# Altium schematic sheet DC_15V_5V.SchDoc
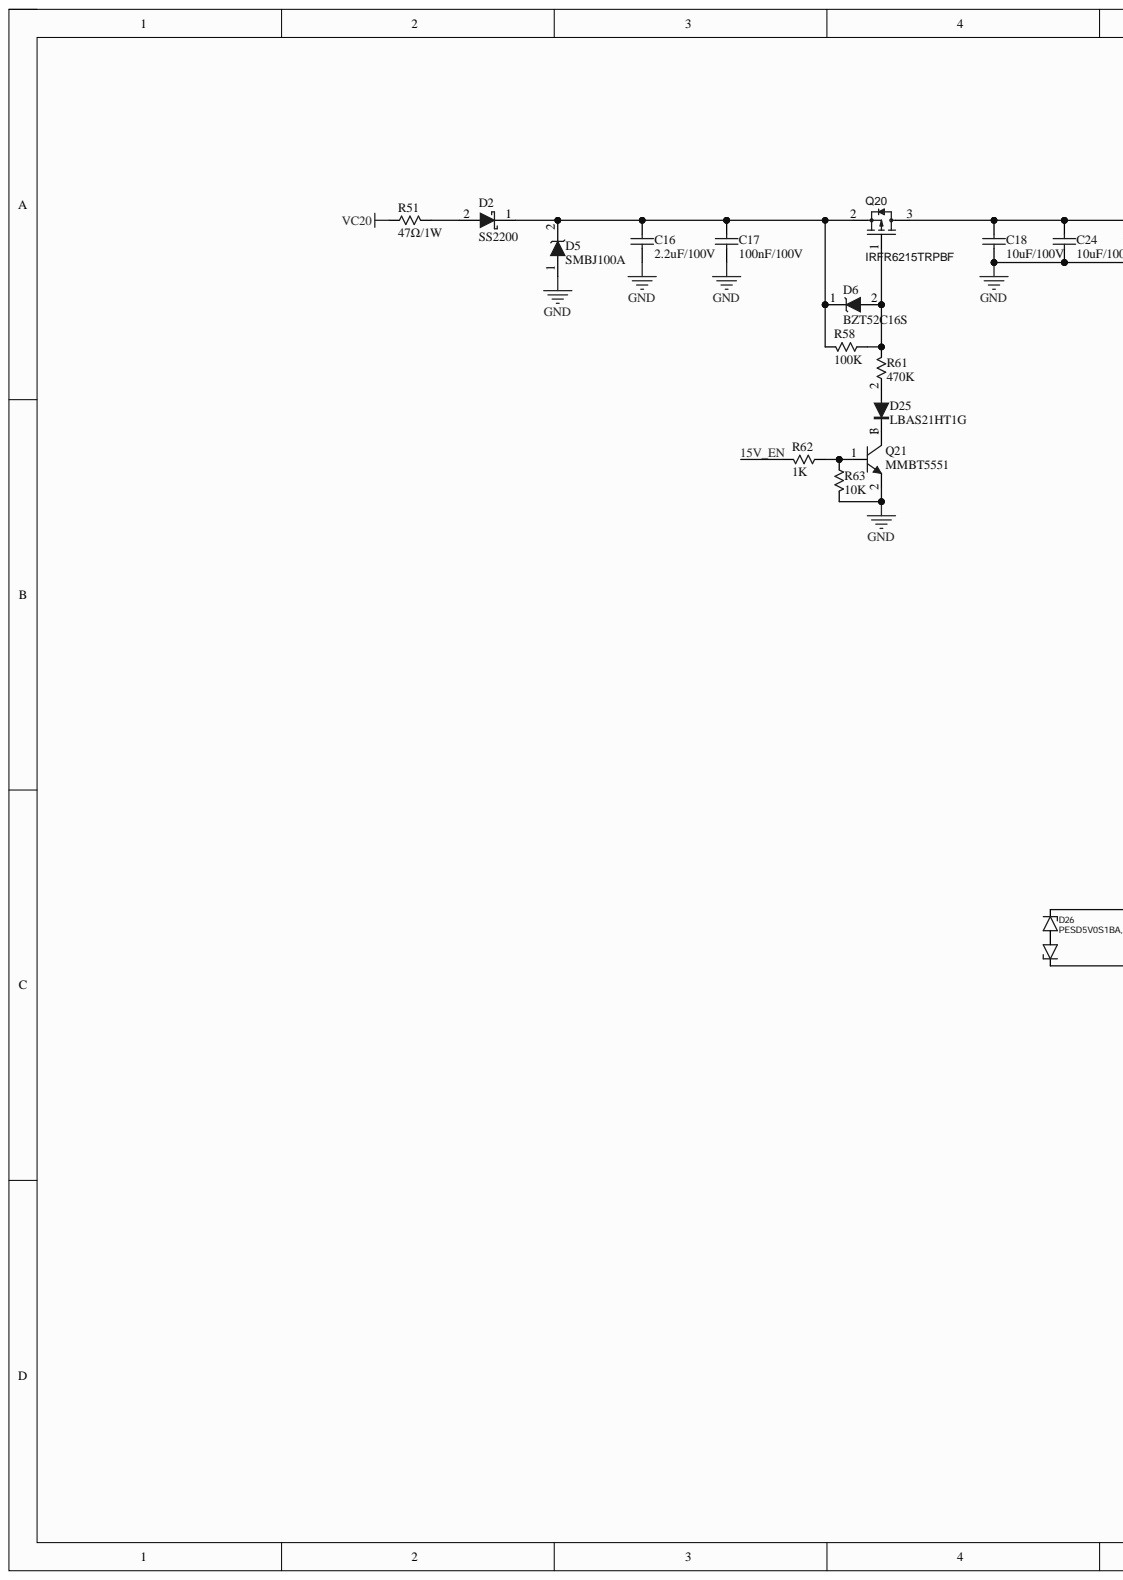
[diagram p0: DC_15V_5V.SchDoc, left]
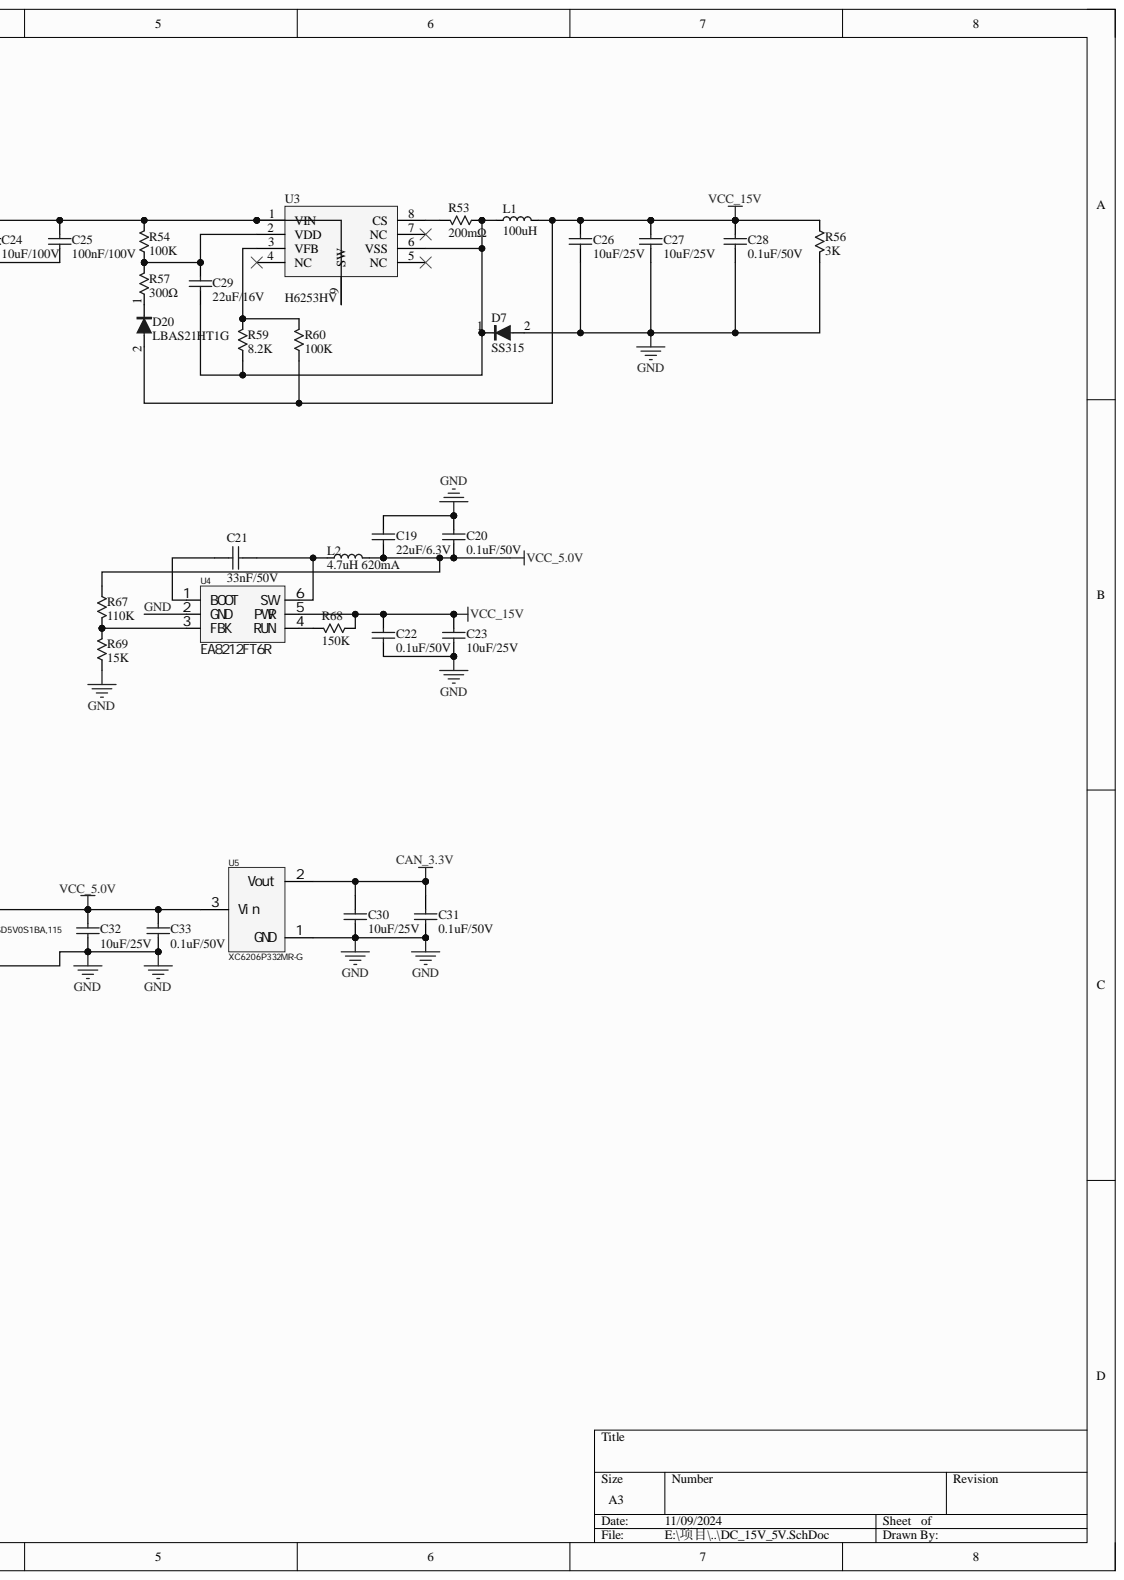
[diagram p1: DC_15V_5V.SchDoc, right]

## Parts
C16  2.2uF/100V  [0805C]
C17  100nF/100V  [0805C]
C18  10uF/100V  [1210C]
C19  22uF/6.3V  [0603_C]
C20  0.1uF/50V  [0603_C]
C21  33nF/50V  [0603_C]
C22  0.1uF/50V  [0603_C]
C23  10uF/25V  [0603_C]
C24  10uF/100V  [1210C]
C25  100nF/100V  [0805C]
C26  10uF/25V  [0603_C]
C27  10uF/25V  [0603_C]
C28  0.1uF/50V  [0603_C]
C29  22uF/16V  [0805C]
C30  10uF/25V  [0603_C]
C31  0.1uF/50V  [0603_C]
C32  10uF/25V  [0603_C]
C33  0.1uF/50V  [0603_C]
D2  SS2200  [SMA/DO-214AC]
D5  SMBJ100A  [SMB/DO-214AA]
D6  BZT52C16S  [SOD-323]
D7  SS315  [SMA/DO-214AC]
D20  LBAS21HT1G  [SOD-323]
D25  LBAS21HT1G  [SOD-323]
D26  PESD5V0S1BA,115  [SOD-323_BI]
L1  100uH  [IND-SMD_L6.0-W6.0_FNR60XXS]
L2  4.7uH 620mA  [L1210]
Q20  IRFR6215TRPBF  [TO_252_2]
Q21  MMBT5551  [SOT-23-3]
R51  47Ω/1W  [2512_R]
R53  200mΩ  [1206R]
R54  100K  [0603_R]
R56  3K  [0603_R]
R57  300Ω  [0603_R]
R58  100K  [0603_R]
R59  8.2K  [0603_R]
R60  100K  [0603_R]
R61  470K  [0603_R]
R62  1K  [0603_R]
R63  10K  [0603_R]
R67  110K  [0603_R]
R68  150K  [0603_R]
R69  15K  [0603_R]
U3  H6253HV  [ESOP-8]
U4  EA8212FT6R  [SOT-23-6]
U5  XC6206P332MR-G  [SOT-23-3]

## Nets
CAN_3.3V: C30.2 C31.1 U5.2
VC20: R51.2
VCC_5.0V: C19.2 C20.2 C32.2 C33.1 D26.1 L2.2 R67.2 U5.3
VCC_15V: C22.2 C23.2 C26.1 C27.1 C28.1 D20.2 L1.2 R56.2 R60.2 R68.2 U4.5(PWR)
15V_EN: R62.1
GND: C16.2 C17.2 C18.2 C19.1 C20.1 C22.1 C23.1 C24.2 C25.2 C26.2 C27.2 C28.2 C30.1 C31.2 C32.1 C33.2 D5.1 D7.2 D26.2 Q21.2 R56.1 R63.1 R69.2 U4.2(GND) U5.1
NetC16_1: C16.1 C17.1 D2.1 D5.2 D6.1 Q20.2 R58.1
NetC18_1: C18.1 C24.1 C25.1 Q20.3 R54.1 U3.1(VIN) U3.9(SW)
NetC21_1: C21.1 L2.1 U4.6(SW)
NetC21_2: C21.2 U4.1(BOOT)
NetC29_1: C29.1 R54.2 R57.1 U3.2(VDD)
NetC29_2: C29.2 D7.1 L1.1 R53.2 R59.2 U3.6(VSS)
NetD2_2: D2.2 R51.1
NetD6_2: D6.2 Q20.1 R58.2 R61.1
NetD20_1: D20.1 R57.2
NetD25_1: D25.1 Q21.3
NetD25_2: D25.2 R61.2
NetQ21_1: Q21.1 R62.2 R63.2
NetR53_1: R53.1 U3.8(CS)
NetR59_1: R59.1 R60.1 U3.3(VFB)
NetR67_1: R67.1 R69.1 U4.3(FBK)
NetR68_1: R68.1 U4.4(RUN)

Not connected: U3 4(NC) 5(NC) 7(NC)

## Library data of the parts
C16-C33 = Cap: Capacitor; Component Kind: Standard; LatestRevisionDate: 17-Jul-2002; LatestRevisionNote: Re-released for DXP Platform.; PackageReference: RAD-0.3; Published: 8-Jun-2000; Signal Integrity: Cap; Simulation: CAP; Library Name: UP-ROBOT Devices.SchLib; Publisher: Altium Limited
  C16: Value: 2.2uF/100V
  C17 C25: Value: 100nF/100V
  C18 C24: Value: 10uF/100V
  C19: Value: 22uF/6.3V
  C20 C22 C28 C31 C33: Value: 0.1uF/50V
  C21: Value: 33nF/50V
  C23 C26 C27 C30 C32: Value: 10uF/25V
  C29: Value: 22uF/16V
D7 D20 D25 = 1N4148W: Component Kind: Standard; Library Name: DVC1124_20S.SchLib
D26 = PESD5V0S1BA,115: Manufacturer: Nexperia(安世); Add into BOM: yes; Breakdown Voltage: 5.5V; Maximum Clamping Voltage: 14V; Peak Pulse Current (Ipp)@10/1000us: 12A; Peak Pulse Power Dissipation (Ppp)@10/1000us: 130W; polarity: 双向; Reverse Stand-Off Voltage (Vrwm): 5V; Type: TVS; Component Kind: Standard; Convert to PCB: yes; Datasheet: https://atta.szlcsc.com/upload/public/pdf/source/20161024/1477288630856.pdf; Device: PESD5V0S1BA,115; JLCPCB Part Class: Extended Part; LCSC Part Name: PESD5V0S1BA,115; Library Name: BMS_20S_MCU_MOS.SchLib; Manufacturer Part: PESD5V0S1BA,115; Supplier: LCSC; Supplier Footprint: SOD-323; Supplier Part: C19224; Symbol: PESD5V0S1BA,115
L1 = Inductor: FNR6045S101MT; Code_IEC: 1005; Code_JEITA: 0402; Component Kind: Standard; LatestRevisionDate: 17-Jul-2002; LatestRevisionNote: Re-released for DXP Platform.; PackageReference: 0402-A; Published: 8-Jun-2000; Signal Integrity: Inductor; Simulation: INDUCTOR; Value: 100uH; Library Name: UP-ROBOT Devices.SchLib; Publisher: Altium Limited
L2 = Inductor: NLCV32T-4R7M-PF; Component Kind: Standard; ComponentLink1Description: 淘宝; ComponentLink1URL: https://item.taobao.com/item.htm?spm=a1z09.2.0.0.2c712e8doApNwR&id=548266716017&_u=o27ne5fpe679; Library Name: MY_SCHLIB.SchLib
Q20 = PMOS: BOM_Manufacturer: Ncepower; BOM_Manufacturer Part: NCE0102; Contributor: LCSC; BOM_JLCPCB Part Class: Extended Part; BOM_Supplier: LCSC; BOM_Supplier Part: C161843; Component Kind: Standard; Library Name: BMS_20S_MCU_MOS.SchLib; spicePre: Q; spiceSymbolName: NCE0102
Q21 = NPN: Component Kind: Standard; Library Name: Three_Axis_Arm_Control_V1.1.SchLib
R51 R53 R54 R56-R63 R67-R69 = Res: Resistor; Component Kind: Standard; LatestRevisionDate: 17-Jul-2002; LatestRevisionNote: Re-released for DXP Platform.; PackageReference: AXIAL-0.3; Published: 8-Jun-2000; Signal Integrity: Res; Simulation: RESISTOR; Library Name: UP-ROBOT Devices.SchLib; Publisher: Altium Limited
  R51: Value: 47Ω/1W
  R53: Value: 200mΩ
  R54 R58 R60: Value: 100K
  R56: Value: 3K
  R57: Value: 300Ω
  R59: Value: 8.2K
  R61: Value: 470K
  R62: Value: 1K
  R63: Value: 10K
  R67: Value: 110K
  R68: Value: 150K
  R69: Value: 15K
U4 = EA8212FT6R: Manufacturer: everanalog(申风); Add into BOM: yes; Function: 降压型; Input Voltage: 4.5V~18V; Output Current (Max): 2A; Output Voltage: 900mV~12V; Switch tube (built-in/external): 内置; Switching Frequency: 500kHz; Component Kind: Standard; Convert to PCB: yes; Datasheet: https://atta.szlcsc.com/upload/public/pdf/source/20230315/38959F4CF9BF836C9EE97E62D66D6936.pdf; Device: EA8212FT6R; JLCPCB Part Class: Extended Part; LCSC Part Name: EA8212FT6R; Library Name: DCDC_Test.SchLib; Manufacturer Part: EA8212FT6R; Number of Outputs: -; Operating Temperature: -; Output Type: -; Quiescent Current (Iq): -; Supplier: LCSC; Supplier Footprint: SOT-23-6; Supplier Part: C5369100; Symbol: EA8212FT6R; Synchronous Rectification: -; Topology: -
U5 = XC6206P332MR-G: Manufacturer: TOREX(特瑞仕); Add into BOM: yes; Device: XC6206P332MR-G_C5446; Dropout Voltage: 680mV@(100mA); MAX Output Current: 200mA; Maximum Input Voltage: 6V; Number of Outputs: 1; Output Polarity: 正极; Output Type: 固定; Output Voltage: 3.3V; Component Kind: Standard; Convert to PCB: yes; Datasheet: https://atta.szlcsc.com/upload/public/pdf/source/20130823/1457706639850.pdf; JLCPCB Part Class: Basic Part; LCSC Part Name: 输入6V 输出3.3V 200mA; Library Name: BMS_20S_MCU_MOS.SchLib; Manufacturer Part: XC6206P332MR-G; Power Supply Rejection Ratio (PSRR): -; Supplier: LCSC; Supplier Footprint: SOT-23-3L; Supplier Part: C5446; Symbol: XC6206P332MR
D2 = SS2200: Component Kind: Standard; Library Name: DVC1124_20S.SchLib
D5 = SMBJ100A: Component Kind: Standard; Library Name: DVC1124_20S.SchLib
D6 = BZT52C16S: Component Kind: Standard; Library Name: DVC1124_20S.SchLib
U3 = H6253HV: Component Kind: Standard; Library Name: DCDC_CAN.SchLib
